# Altium schematic sheet Fuente_3.3V.SchDoc
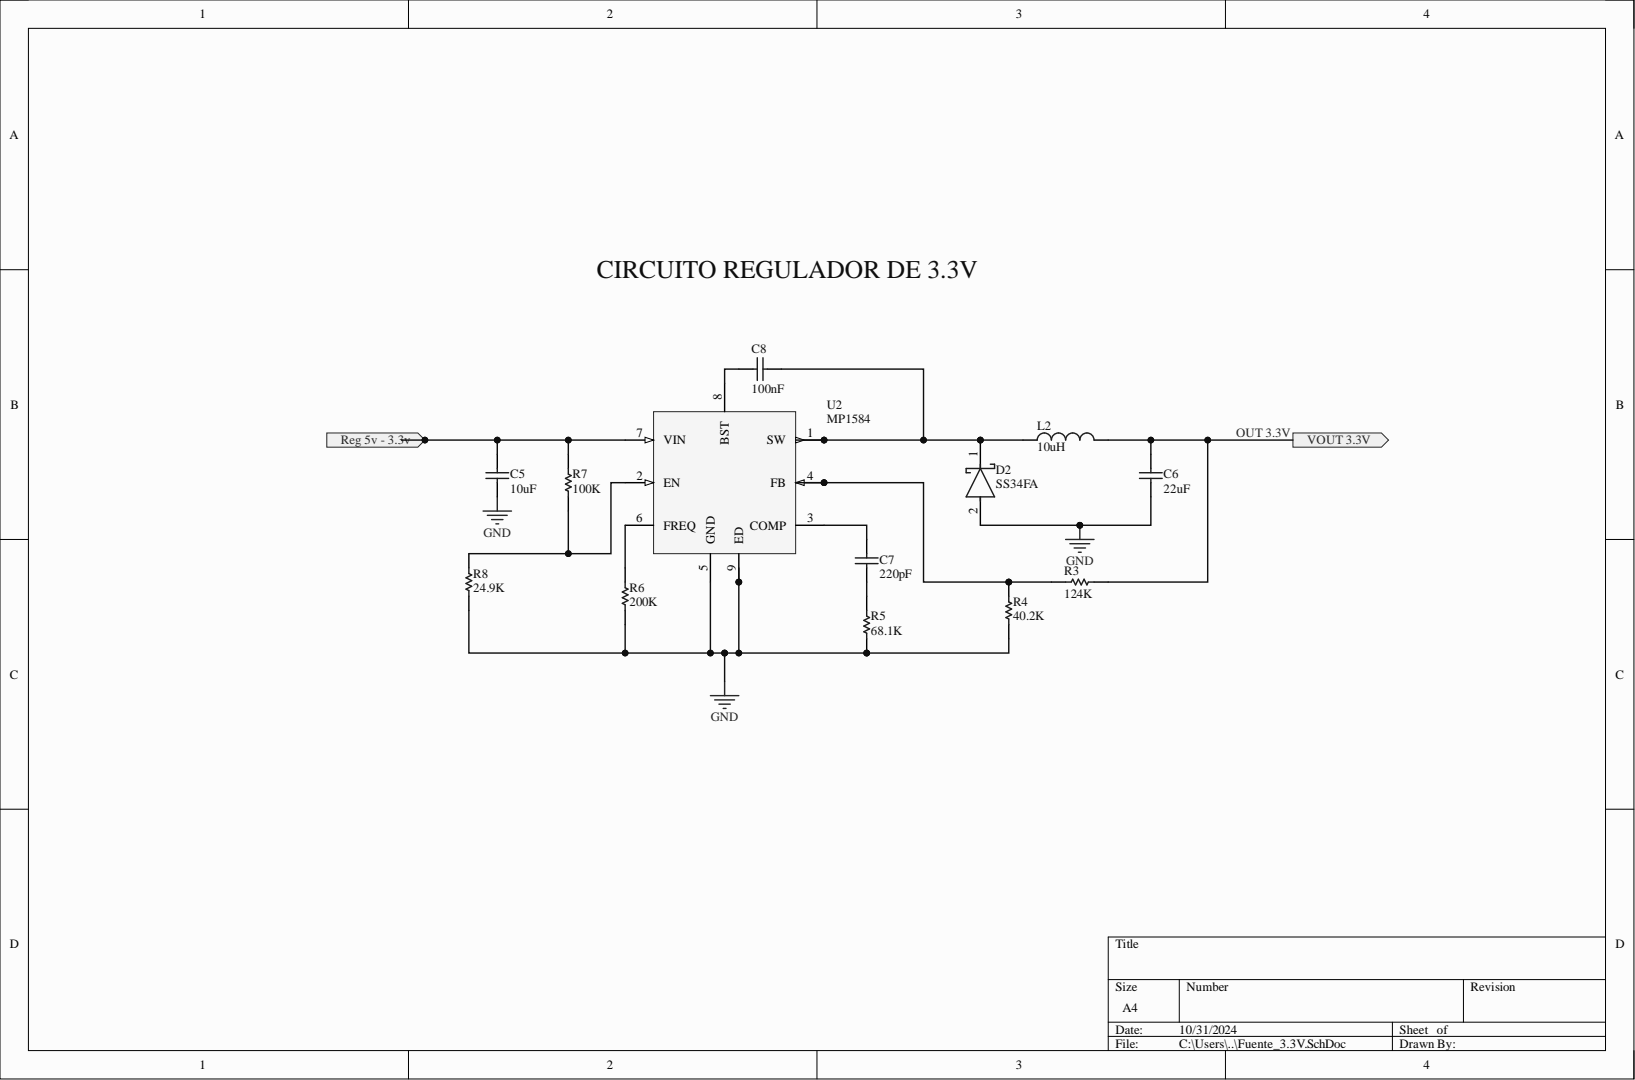
[diagram p0: Fuente_3.3V.SchDoc]

## Parts
C5  value 10uF  [CC0805]  (hidden comment: Cap)
C6  value 22uF  [CC0805]  (hidden comment: Cap)
C7  value 220pF  [CC0805]  (hidden comment: Cap)
C8  value 100nF  [CC0805]  (hidden comment: Cap)
D2  SS34FA  [Diodo_SS34]
L2  10uH  [inductor 10uH-47uH]
R3  1%  value 124K  [RES 1206]
R4  value 40.2K  [RES 1206]  (hidden comment: 1%)
R5  value 68.1K  [RES 1206]  (hidden comment: 1%)
R6  value 200K  [RES 1206]  (hidden comment: 1%)
R7  1%  value 100K  [RES 1206]
R8  value 24.9K  [RES 1206]  (hidden comment: 1%)
U2  MP1584  [MP1584-LF-Z]

## Ports
Reg 5v - 3.3v, VOUT 3.3V

## Nets
GND: C5.1 C6.1 D2.2 R4.1 R5.1 R6.1 R8.1 U2.5(GND) U2.9(ED)
OUT 3.3V: C6.2 L2.2 R3.2 ; port VOUT 3.3V
Reg 5v - 3.3v: C5.2 R7.2 U2.7(VIN) ; port Reg 5v - 3.3v
NetC7_1: C7.1 R5.2
NetC7_2: C7.2 U2.3(COMP)
NetC8_1: C8.1 U2.8(BST)
NetC8_2: C8.2 D2.1 L2.1 U2.1(SW)
NetR3_1: R3.1 R4.2 U2.4(FB)
NetR6_2: R6.2 U2.6(FREQ)
NetR7_1: R7.1 R8.2 U2.2(EN)

## Library data of the parts
C5-C8 = Cap_1: Capacitor; Component Kind: Standard; LatestRevisionDate: 17-Jul-2002; LatestRevisionNote: Re-released for DXP Platform.; PackageDescription: Radial Cap, Thru-Hole; 2 Leads; 0.3 in Pin Spacing; PackageReference: RAD-0.3; Published: 8-Jun-2000; Library Name: biblioteca esquematico sistema de drenaje.SchLib; Publisher: Altium Limited
D2 = SS34FA: DIODE SCHOTTKY 40V 3A SOD123W; Component Kind: Standard; RoHS Compliant: Yes; Library Name: biblioteca esquematico sistema de drenaje.SchLib
L2 = Inductor: Code_IEC: 1005; Value: 4A; Code_JEITA: 0402; Component Kind: Standard; Description: Inductor; LatestRevisionDate: 29-May-2009; LatestRevisionNote: IPC-7351 Footprint Added.; PackageDescription: Chip Inductor; PackageReference: 0402-A; Published: 8-Jun-2000; Library Name: biblioteca esquematico sistema de drenaje.SchLib; Publisher: Altium Limited
R3-R8 = Resistor: Component Kind: Standard; Description: Resistor; LatestRevisionDate: 17-Jul-2002; LatestRevisionNote: Re-released for DXP Platform.; PackageDescription: Axial Device, Thru-Hole; 2 Leads; 0.3 in Pin Spacing; PackageReference: AXIAL-0.3; Published: 8-Jun-2000; Library Name: biblioteca esquematico sistema de drenaje.SchLib; Publisher: Altium Limited
U2 = MP1584: REGULADOR DE 3.3V; Component Kind: Standard; Library Name: biblioteca esquematico sistema de drenaje.SchLib
